# Altium schematic sheet 10_ATK_RK3588S_VCCIO1&4.SchDoc
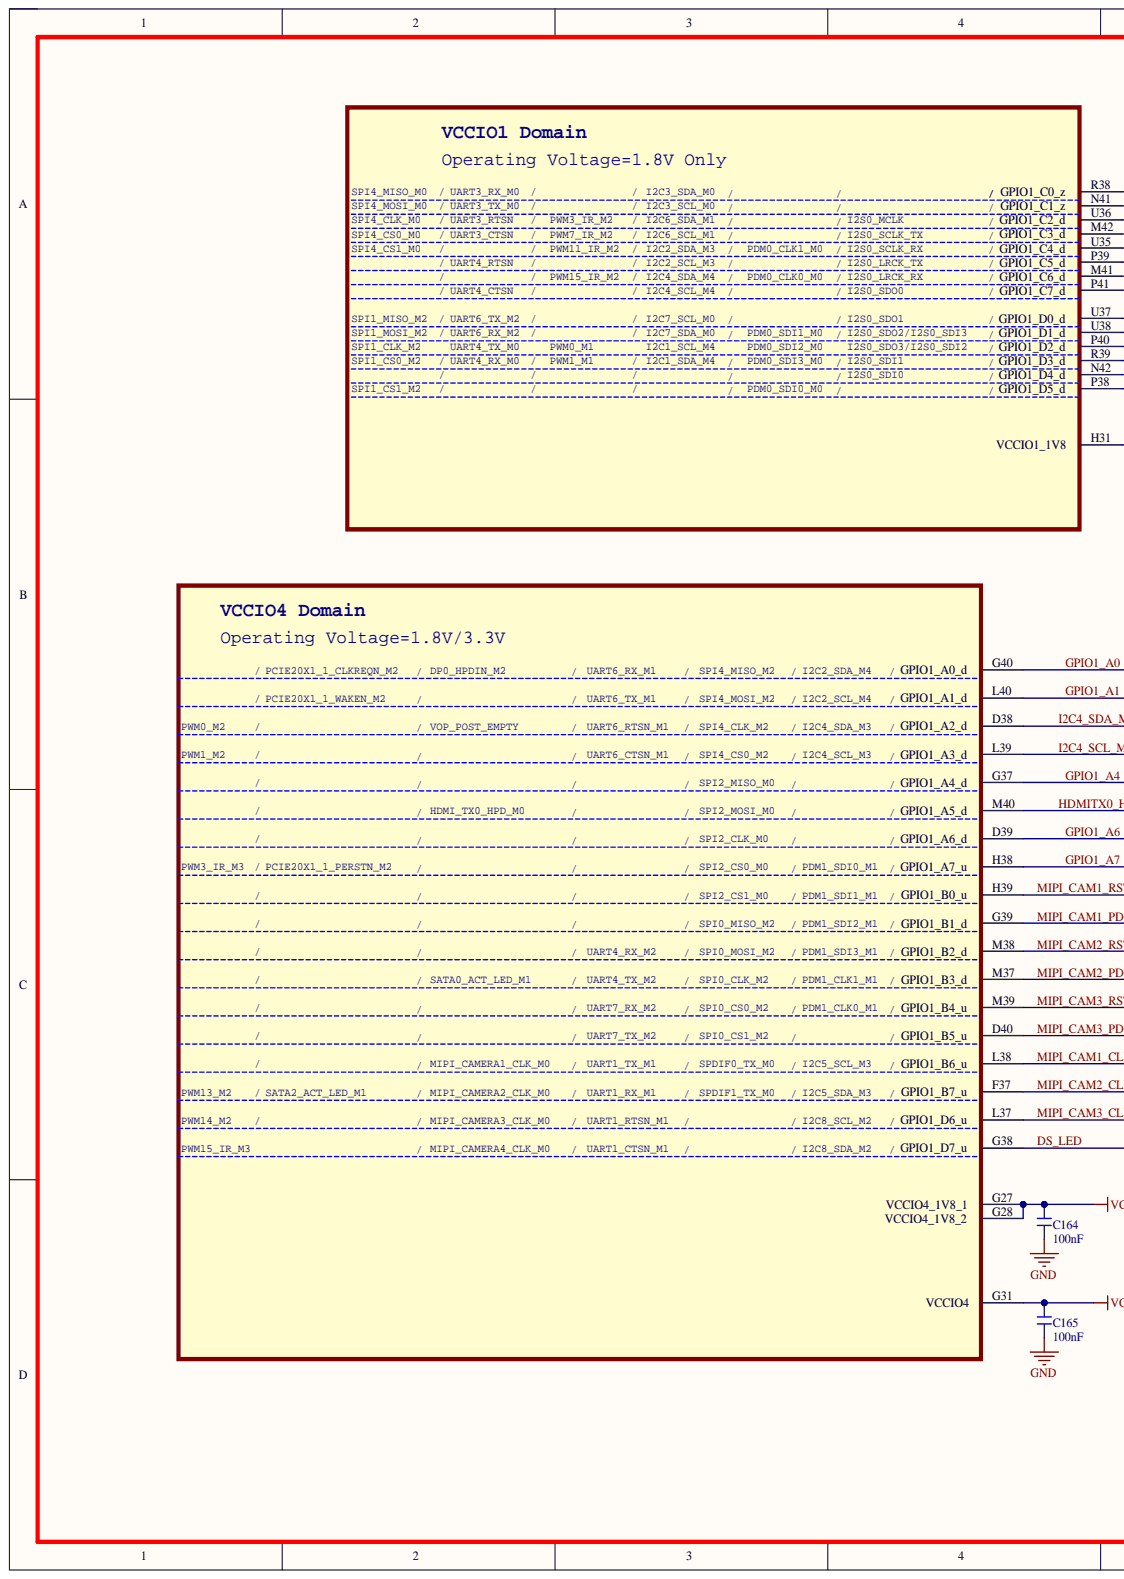
[diagram p0: 10_ATK_RK3588S_VCCIO1&4.SchDoc, left]
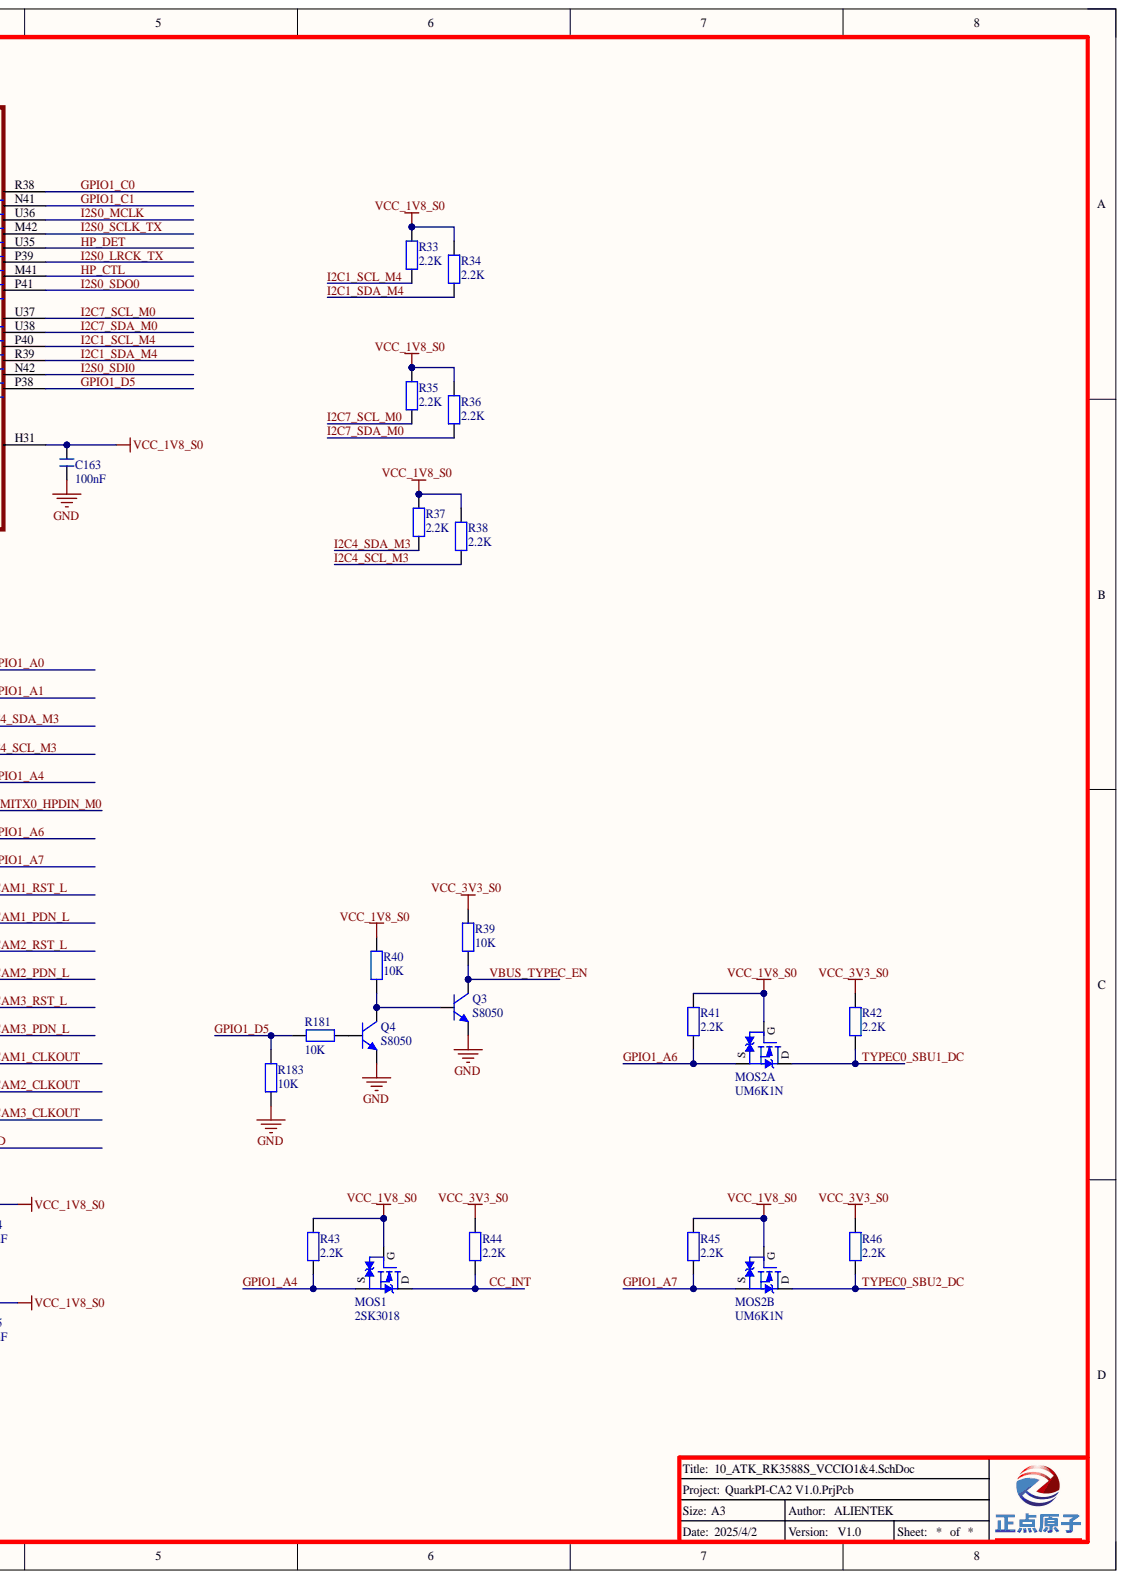
[diagram p1: 10_ATK_RK3588S_VCCIO1&4.SchDoc, right]

## Parts
C163  100nF  [C 0201]
C164  100nF  [C 0201]
C165  100nF  [C 0201]
MOS1  2SK3018  [SOT323-3L]
MOS2  UM6K1N  [SOT363-6L]  (parts A,B)
Q3  S8050  [SOT23-3N]
Q4  S8050  [SOT23-3N]
R33  2.2K  [R 0201]
R34  2.2K  [R 0201]
R35  2.2K  [R 0201]
R36  2.2K  [R 0201]
R37  2.2K  [R 0201]
R38  2.2K  [R 0201]
R39  10K  [R 0402]
R40  10K  [R 0402]
R41  2.2K  [R 0201]
R42  2.2K  [R 0201]
R43  2.2K  [R 0402]
R44  2.2K  [R 0402]
R45  2.2K  [R 0201]
R46  2.2K  [R 0201]
R181  10K  [R 0402]
R183  10K  [R 0402]
U1  RK3588S  [BGA1253_65RX65RX45R_S]  (value not drawn)  (parts G,H)

## Nets
GND: C163.1 C164.1 C165.1 Q3.2 Q4.2 R183.2
VCC_1V8_S0: C163.2 C164.2 C165.2 MOS1.1 MOS2.2 MOS2.5 R33.2 R34.2 R35.2 R36.2 R37.2 R38.2 R40.1 R41.2 R43.2 R45.2 U1.G27(VCCIO4_1V8_1) U1.G28(VCCIO4_1V8_2) U1.G31(VCCIO4) U1.H31(VCCIO1_1V8)
VCC_3V3_S0: R39.1 R42.2 R44.2 R46.2
CC_INT: MOS1.3 R44.1
DS_LED: U1.G38(GPIO1_D7_u)
GPIO1_A0: U1.G40(GPIO1_A0_d)
GPIO1_A1: U1.L40(GPIO1_A1_d)
GPIO1_A4: MOS1.2 R43.1 U1.G37(GPIO1_A4_d)
GPIO1_A6: MOS2.1 R41.1 U1.D39(GPIO1_A6_d)
GPIO1_A7: MOS2.4 R45.1 U1.H38(GPIO1_A7_u)
GPIO1_C0: U1.R38(GPIO1_C0_z)
GPIO1_C1: U1.N41(GPIO1_C1_z)
GPIO1_D5: R181.2 R183.1 U1.P38(GPIO1_D5_d)
HDMITX0_HPDIN_M0: U1.M40(GPIO1_A5_d)
HP_CTL: U1.M41(GPIO1_C6_d)
HP_DET: U1.U35(GPIO1_C4_d)
I2C1_SCL_M4: R33.1 U1.P40(GPIO1_D2_d)
I2C1_SDA_M4: R34.1 U1.R39(GPIO1_D3_d)
I2C4_SCL_M3: R38.1 U1.L39(GPIO1_A3_d)
I2C4_SDA_M3: R37.1 U1.D38(GPIO1_A2_d)
I2C7_SCL_M0: R35.1 U1.U37(GPIO1_D0_d)
I2C7_SDA_M0: R36.1 U1.U38(GPIO1_D1_d)
I2S0_LRCK_TX: U1.P39(GPIO1_C5_d)
I2S0_MCLK: U1.U36(GPIO1_C2_d)
I2S0_SCLK_TX: U1.M42(GPIO1_C3_d)
I2S0_SDI0: U1.N42(GPIO1_D4_d)
I2S0_SDO0: U1.P41(GPIO1_C7_d)
MIPI_CAM1_CLKOUT: U1.L38(GPIO1_B6_u)
MIPI_CAM1_PDN_L: U1.G39(GPIO1_B1_d)
MIPI_CAM1_RST_L: U1.H39(GPIO1_B0_u)
MIPI_CAM2_CLKOUT: U1.F37(GPIO1_B7_u)
MIPI_CAM2_PDN_L: U1.M37(GPIO1_B3_d)
MIPI_CAM2_RST_L: U1.M38(GPIO1_B2_d)
MIPI_CAM3_CLKOUT: U1.L37(GPIO1_D6_u)
MIPI_CAM3_PDN_L: U1.D40(GPIO1_B5_u)
MIPI_CAM3_RST_L: U1.M39(GPIO1_B4_u)
TYPEC0_SBU1_DC: MOS2.6 R42.1
TYPEC0_SBU2_DC: MOS2.3 R46.1
VBUS_TYPEC_EN: Q3.3 R39.2
NetQ3_1: Q3.1 Q4.3 R40.2
NetQ4_1: Q4.1 R181.1

## Library data of the parts
C163-C165 = C: 无极性电容; BOM名称: 无极性贴片电容; 版权归属: 正点原子; Component Kind: Standard; Library Name: 正点原子封装库V1.0.IntLib; 学习资源: www.openedv.com
MOS1 = 2SK3018: NMOS  30V 0.1A@2.5V 8Ω; BOM名称: N沟场效应管; Parameter: MOS管; 版权归属: 正点原子; Component Kind: Standard; Library Name: ATK-DLRK3588B V0.2.IntLib; 学习资源: www.openedv.com
MOS2 = DUAL NMOS: NMOS; BOM名称: N沟道场效应管; Parameter: MOS管; 版权归属: 正点原子; Component Kind: Standard; Library Name: MOS管.SchLib; 学习资源: www.openedv.com
Q3 Q4 = 8050-SMD: 高频放大-NPN型; Parameter: 三极管; 属性: 40V 1.5A 1W 100MHZ; 版权归属: 正点原子; BOM名称: 高频放大-NPN型; Component Kind: Standard; Library Name: 正点原子封装库V1.0.IntLib; 学习资源: www.openedv.com
R33-R46 R181 R183 = R: 电阻; BOM名称: 贴片电阻; 版权归属: 正点原子; Component Kind: Standard; Library Name: 正点原子封装库V1.0.IntLib; Parameter: 电阻; 学习资源: www.openedv.com
U1 = RK3588S: SPLIT_INST: TRUE; SWAP_INFO: (S1+S2+S3+S4+S5+S6+S7+S8+S9+S10+S11+S12+S13+S14+S15+S16+S17+S18+S19+S20+S21+S22+S23+S24+S25+S26); Component Kind: Standard; Library Name: atompi-ca2.SchLib
